# Altium schematic sheet Inresol_grid_inverter_DSPIC.SchDoc(Inresol_grid_inverter_DSPIC)
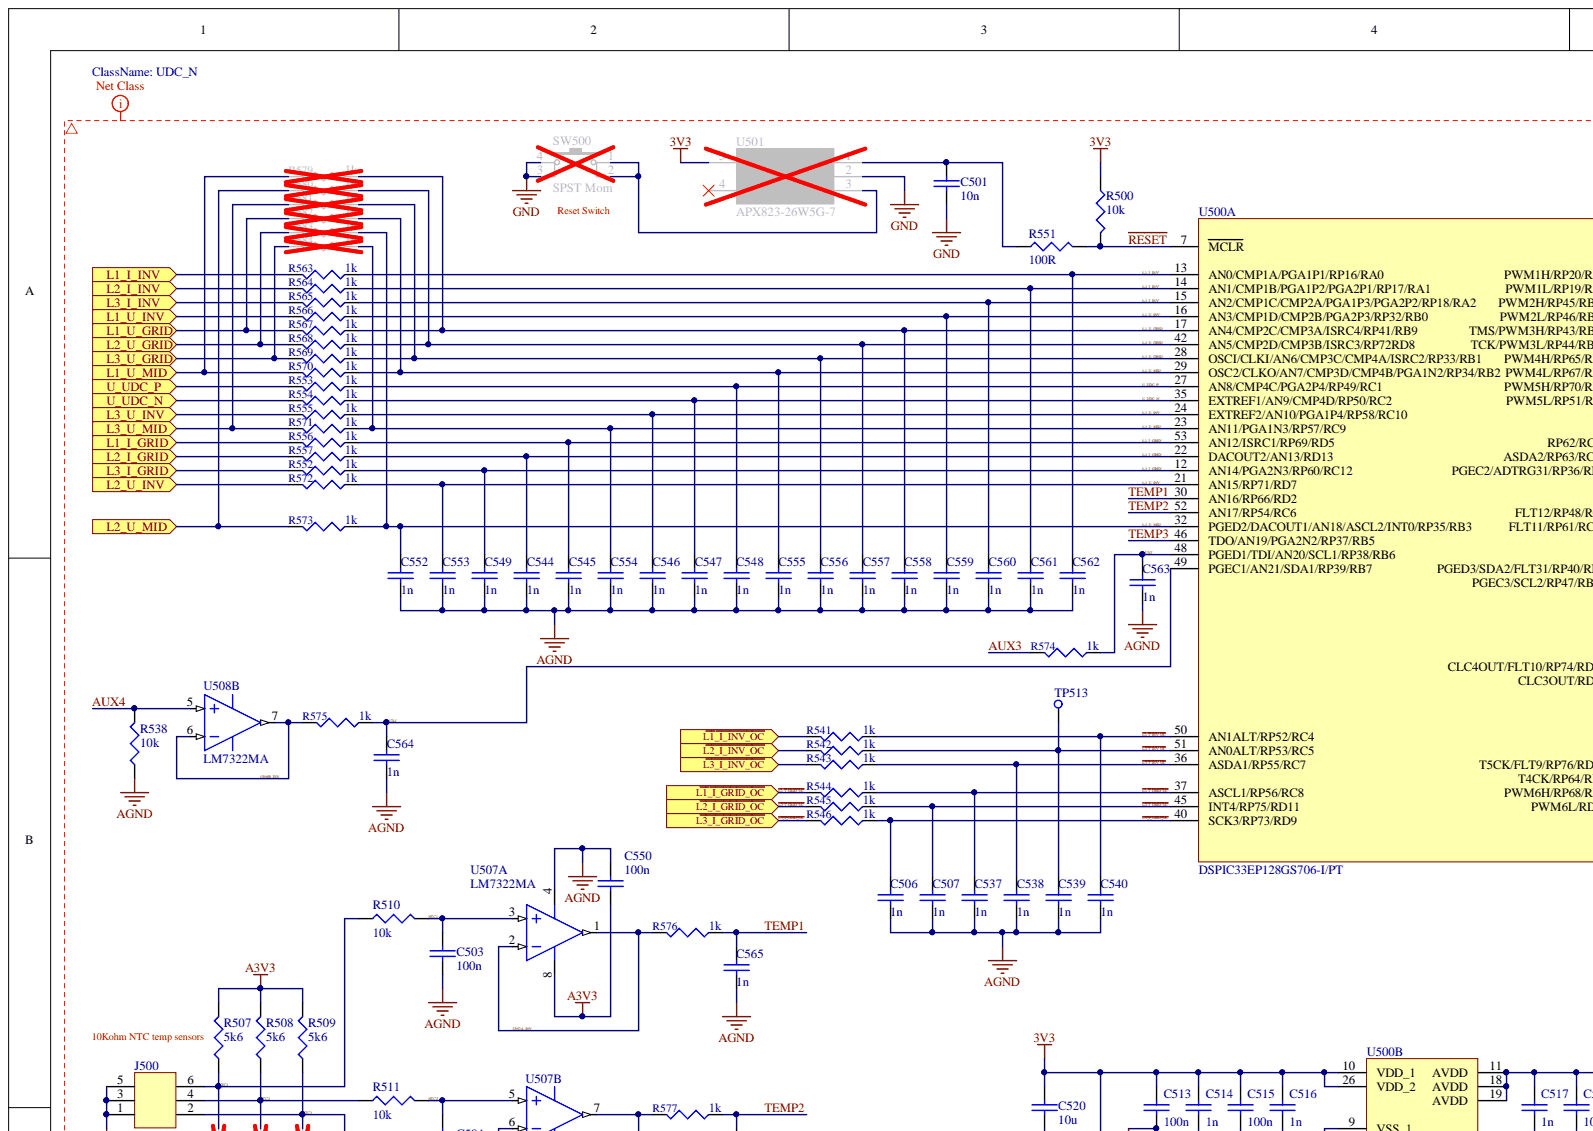
[diagram p0: Inresol_grid_inverter_DSPIC.SchDoc, top left]
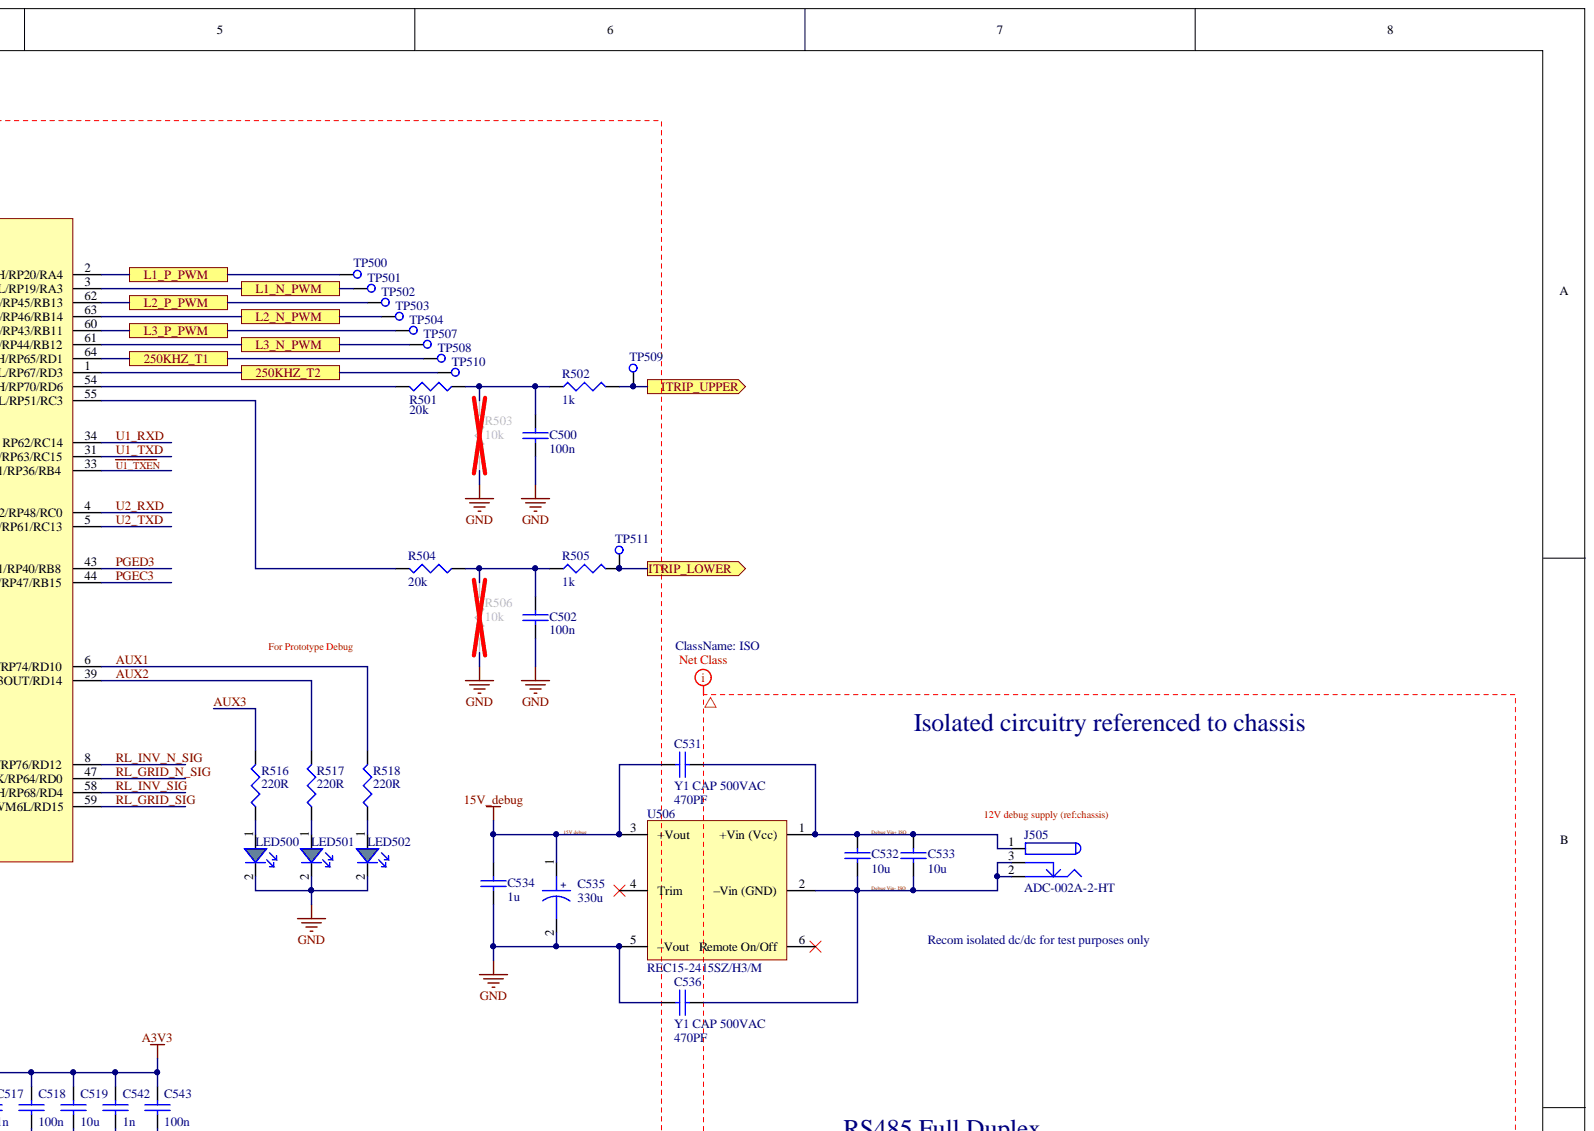
[diagram p1: Inresol_grid_inverter_DSPIC.SchDoc, top right]
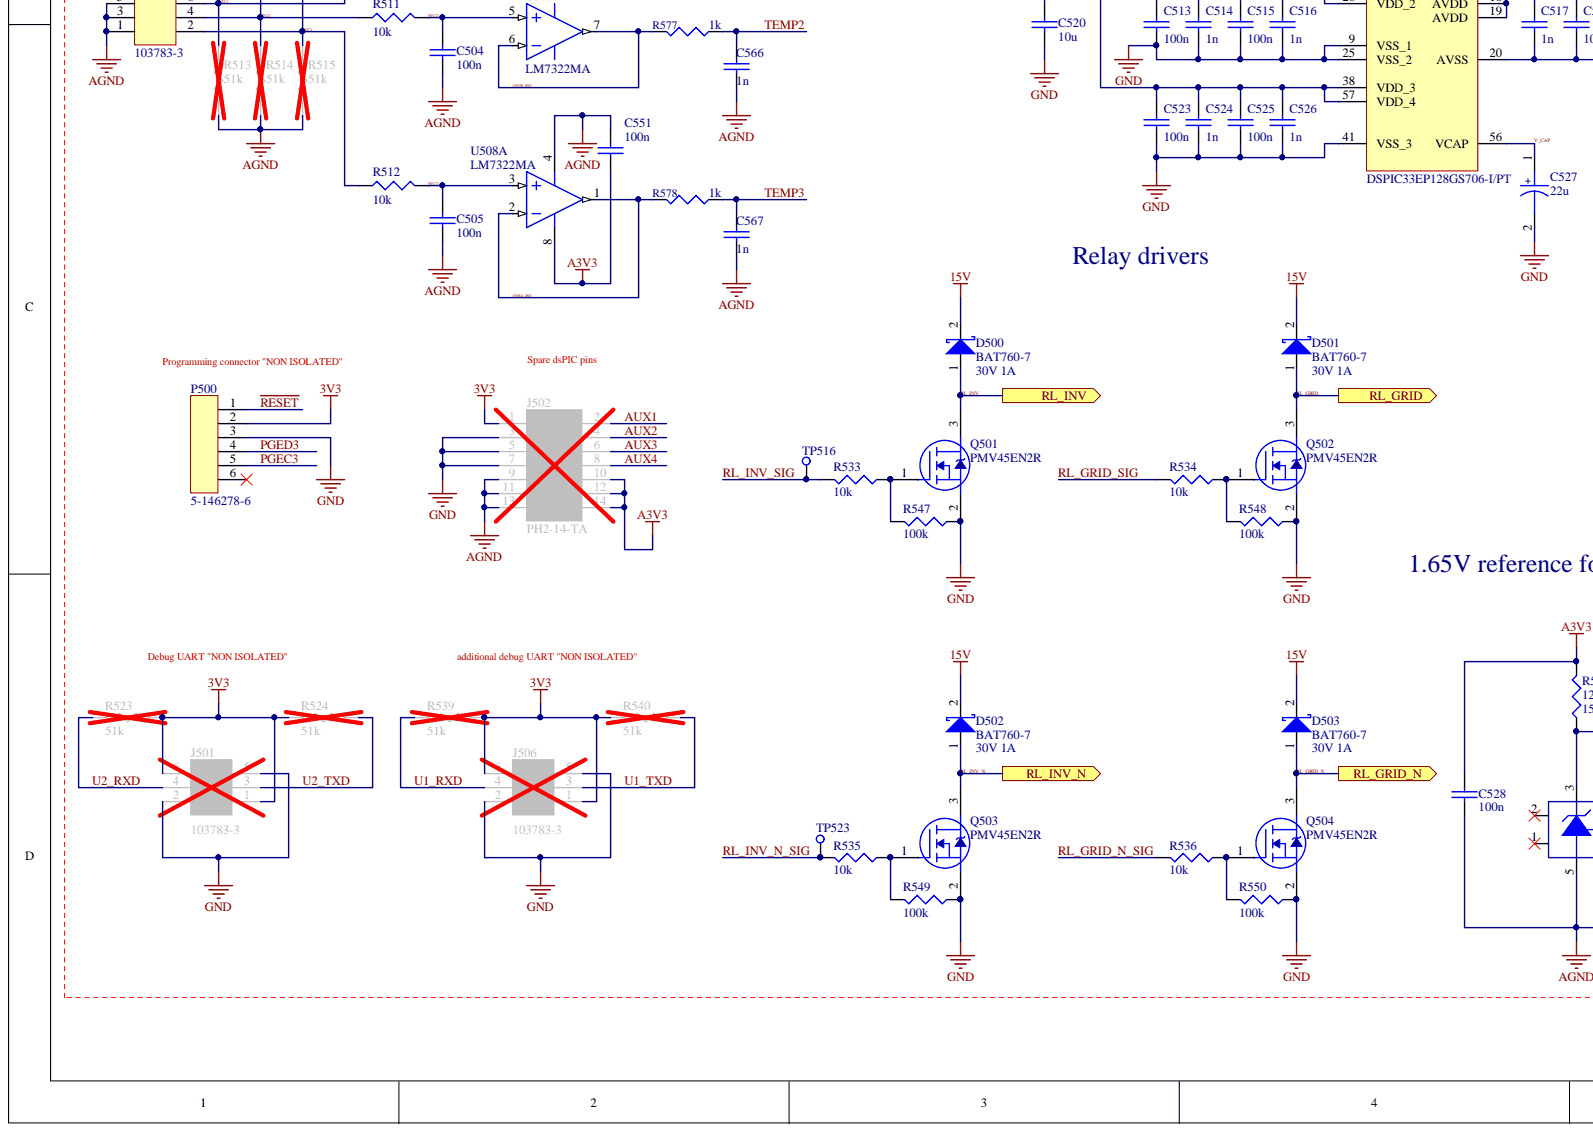
[diagram p2: Inresol_grid_inverter_DSPIC.SchDoc, bottom left]
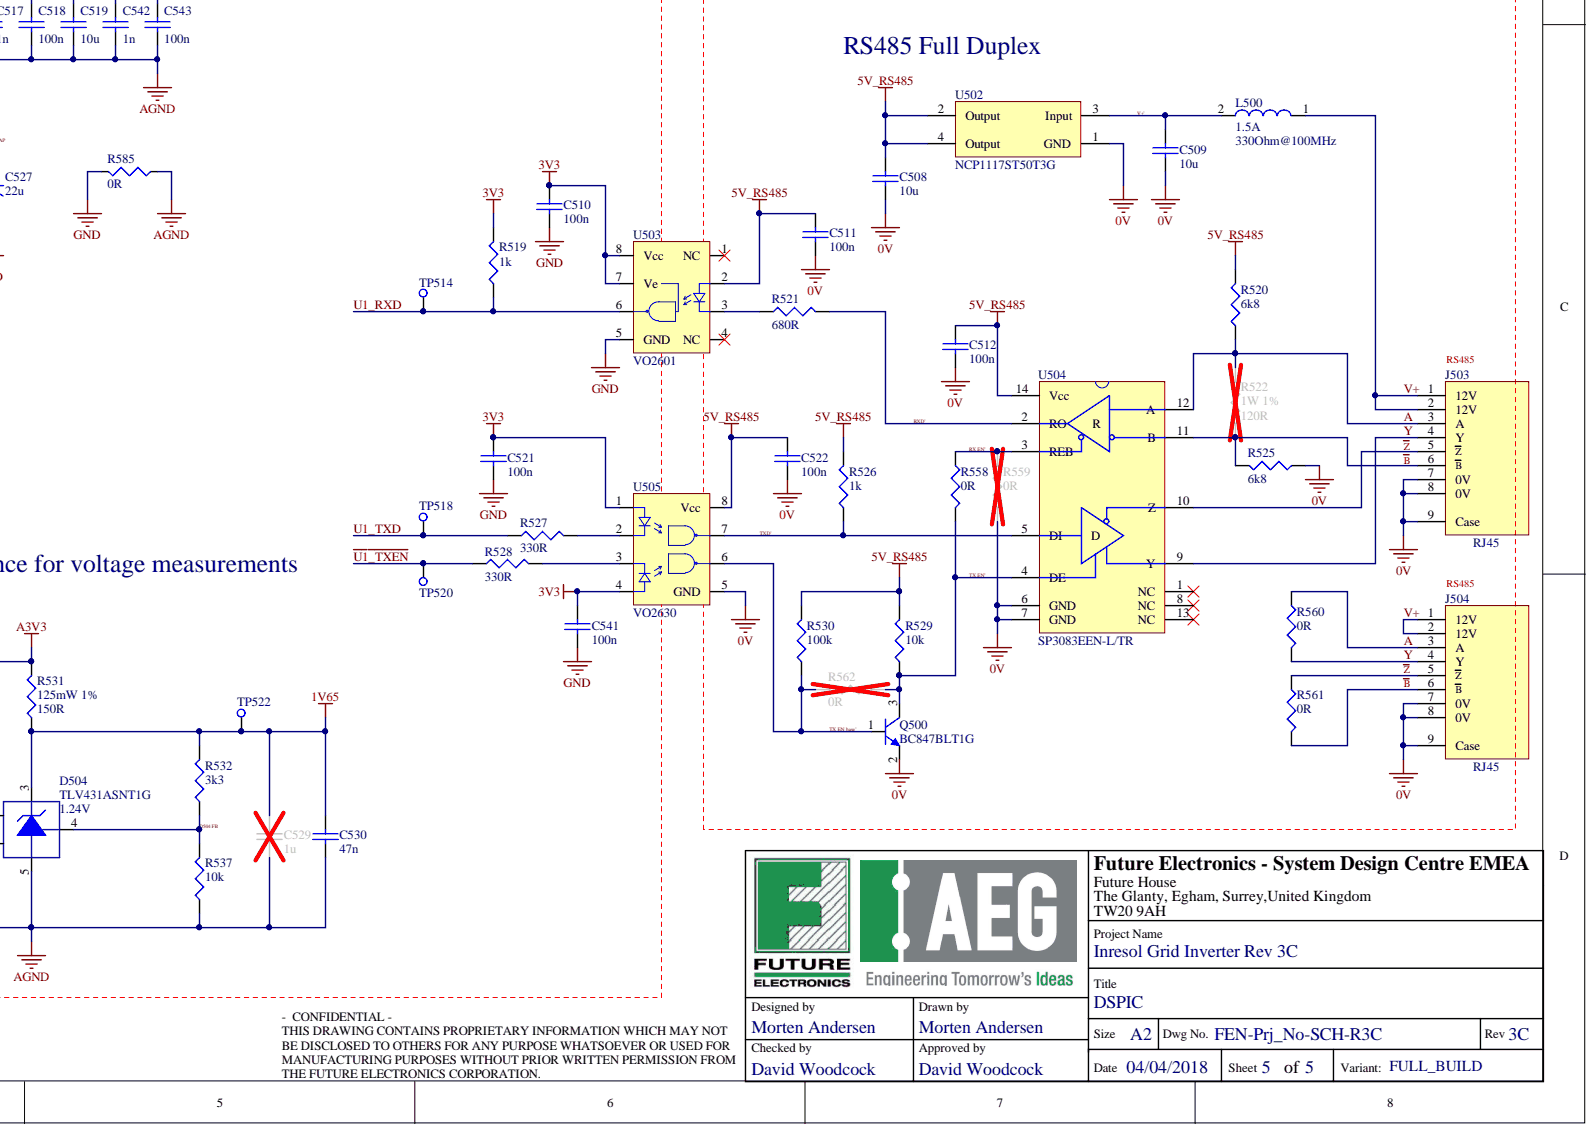
[diagram p3: Inresol_grid_inverter_DSPIC.SchDoc, bottom right]

## Parts
C500  value 100n  [0603]  (hidden comment: C-100nF-50V-Cer-0603)
C501  value 10n  [0603]  (hidden comment: C-10nF-25V-Cer-0603)
C502  value 100n  [0603]  (hidden comment: C-100nF-50V-Cer-0603)
C503  value 100n  [0603]  (hidden comment: C-100nF-50V-Cer-0603)
C504  value 100n  [0603]  (hidden comment: C-100nF-50V-Cer-0603)
C505  value 100n  [0603]  (hidden comment: C-100nF-50V-Cer-0603)
C506  value 1n  [0603]  (hidden comment: C-1nF-50V-Cer-0603)
C507  value 1n  [0603]  (hidden comment: C-1nF-50V-Cer-0603)
C508  value 10u  [1206]  (hidden comment: C-10uF-10V-Tant-1206)
C509  value 10u  [1206]  (hidden comment: C-10uF-50V-Cer-1206)
C510  value 100n  [0603]  (hidden comment: C-100nF-50V-Cer-0603)
C511  value 100n  [0603]  (hidden comment: C-100nF-50V-Cer-0603)
C512  value 100n  [0603]  (hidden comment: C-100nF-50V-Cer-0603)
C513  value 100n  [0603]  (hidden comment: C-100nF-50V-Cer-0603)
C514  value 1n  [0603]  (hidden comment: C-1nF-50V-Cer-0603)
C515  value 100n  [0603]  (hidden comment: C-100nF-50V-Cer-0603)
C516  value 1n  [0603]  (hidden comment: C-1nF-50V-Cer-0603)
C517  value 1n  [0603]  (hidden comment: C-1nF-50V-Cer-0603)
C518  value 100n  [0603]  (hidden comment: C-100nF-50V-Cer-0603)
C519  value 10u  [1206]  (hidden comment: C-10uF-10V-Cer-1206)
C520  value 10u  [1206]  (hidden comment: C-10uF-10V-Cer-1206)
C521  value 100n  [0603]  (hidden comment: C-100nF-50V-Cer-0603)
C522  value 100n  [0603]  (hidden comment: C-100nF-50V-Cer-0603)
C523  value 100n  [0603]  (hidden comment: C-100nF-50V-Cer-0603)
C524  value 1n  [0603]  (hidden comment: C-1nF-50V-Cer-0603)
C525  value 100n  [0603]  (hidden comment: C-100nF-50V-Cer-0603)
C526  value 1n  [0603]  (hidden comment: C-1nF-50V-Cer-0603)
C527  value 22u  [1210(C3225)L-Tant]  (hidden comment: C-22uF-16V-Tant-1210)
C528  value 100n  [0603]  (hidden comment: C-100nF-50V-Cer-0603)
C529  value 1u  [0603]  (hidden comment: C-1uF-25V-Cer-0603)
C530  value 47n  [0603]  (hidden comment: C-47nF-50V-Cer-0603)
C531  value 470PF  Rating: Y1 CAP 500VAC  [C-10.5x8.5_LS10]  (hidden comment: C-470PF-500VAC-Cer-8X5-LS10-Y1-EMEA-06-09-2017)
C532  value 10u  [1206]  (hidden comment: C-10uF-50V-Cer-1206)
C533  value 10u  [1206]  (hidden comment: C-10uF-50V-Cer-1206)
C534  value 1u  [0603]  (hidden comment: C-1uF-25V-Cer-0603)
C535  value 330u  [C-SM_R8x10]  (hidden comment: C-330uF-25V-Elec-8x10-EMEA-06-09-2017)
C536  value 470PF  Rating: Y1 CAP 500VAC  [C-10.5x8.5_LS10]  (hidden comment: C-470PF-500VAC-Cer-8X5-LS10-Y1-EMEA-06-09-2017)
C537  value 1n  [0603]  (hidden comment: C-1nF-50V-Cer-0603)
C538  value 1n  [0603]  (hidden comment: C-1nF-50V-Cer-0603)
C539  value 1n  [0603]  (hidden comment: C-1nF-50V-Cer-0603)
C540  value 1n  [0603]  (hidden comment: C-1nF-50V-Cer-0603)
C541  value 100n  [0603]  (hidden comment: C-100nF-50V-Cer-0603)
C542  value 1n  [0603]  (hidden comment: C-1nF-50V-Cer-0603)
C543  value 100n  [0603]  (hidden comment: C-100nF-50V-Cer-0603)
C544  value 1n  [0603]  (hidden comment: C-1nF-50V-Cer-0603)
C545  value 1n  [0603]  (hidden comment: C-1nF-50V-Cer-0603)
C546  value 1n  [0603]  (hidden comment: C-1nF-50V-Cer-0603)
C547  value 1n  [0603]  (hidden comment: C-1nF-50V-Cer-0603)
C548  value 1n  [0603]  (hidden comment: C-1nF-50V-Cer-0603)
C549  value 1n  [0603]  (hidden comment: C-1nF-50V-Cer-0603)
C550  value 100n  [0603]  (hidden comment: C-100nF-50V-Cer-0603)
C551  value 100n  [0603]  (hidden comment: C-100nF-50V-Cer-0603)
C552  value 1n  [0603]  (hidden comment: C-1nF-50V-Cer-0603)
C553  value 1n  [0603]  (hidden comment: C-1nF-50V-Cer-0603)
C554  value 1n  [0603]  (hidden comment: C-1nF-50V-Cer-0603)
C555  value 1n  [0603]  (hidden comment: C-1nF-50V-Cer-0603)
C556  value 1n  [0603]  (hidden comment: C-1nF-50V-Cer-0603)
C557  value 1n  [0603]  (hidden comment: C-1nF-50V-Cer-0603)
C558  value 1n  [0603]  (hidden comment: C-1nF-50V-Cer-0603)
C559  value 1n  [0603]  (hidden comment: C-1nF-50V-Cer-0603)
C560  value 1n  [0603]  (hidden comment: C-1nF-50V-Cer-0603)
C561  value 1n  [0603]  (hidden comment: C-1nF-50V-Cer-0603)
C562  value 1n  [0603]  (hidden comment: C-1nF-50V-Cer-0603)
C563  value 1n  [0603]  (hidden comment: C-1nF-50V-Cer-0603)
C564  value 1n  [0603]  (hidden comment: C-1nF-50V-Cer-0603)
C565  value 1n  [0603]  (hidden comment: C-1nF-50V-Cer-0603)
C566  value 1n  [0603]  (hidden comment: C-1nF-50V-Cer-0603)
C567  value 1n  [0603]  (hidden comment: C-1nF-50V-Cer-0603)
D500  MFR PN: BAT760-7  Rating: 30V 1A  [SOD323]  (hidden comment: D-SOD323-BAT760-7)
D501  MFR PN: BAT760-7  Rating: 30V 1A  [SOD323]  (hidden comment: D-SOD323-BAT760-7)
D502  MFR PN: BAT760-7  Rating: 30V 1A  [SOD323]  (hidden comment: D-SOD323-BAT760-7)
D503  MFR PN: BAT760-7  Rating: 30V 1A  [SOD323]  (hidden comment: D-SOD323-BAT760-7)
D504  MFR PN: TLV431ASNT1G  Rating: 1.24V  [TSOP5]  (hidden comment: D-TSOP5-VREF-TLV431A)
J500  MFR PN: 103783-3  [HDR100-2X3-TH]
J501  MFR PN: 103783-3  [HDR100-2X3-TH]
J502  MFR PN: PH2-14-TA  [HDR100-2X7-TH]  (hidden comment: J-HDR100-2x7-TH)
J503  value RJ45  [RJ-45-106066-2]  (hidden comment: J-RJ45-106066-2-EMEA-30-11-2016)
J504  value RJ45  [RJ-45-106066-2]  (hidden comment: J-RJ45-106066-2-EMEA-30-11-2016)
J505  MFR PN: ADC-002A-2-HT  [J-DCJACK_2.5]  (hidden comment: J-ADC-002A-1-2mm-EMEA-24-08-2017)
J506  MFR PN: 103783-3  [HDR100-2X3-TH]
L500  value 330Ohm@100MHz  Rating: 1.5A  [0805[2012]]  (hidden comment: 330 Ohm 1.5A)
LED500  LED-0603-Ever  [0603[1608] - DIODE]  (value not drawn)
LED501  LED-0603-Ever  [0603[1608] - DIODE]  (value not drawn)
LED502  LED-0603-Ever  [0603[1608] - DIODE]  (value not drawn)
P500  MFR PN: 5-146278-6  [HDR100-6PIN-TH]  (hidden comment: J-HDR100-6PIN-TH_TE)
Q500  value BC847BLT1G  MFR PN: BC847BLT1G  [SOT23]  (hidden comment: Q-SOT23-BC847BLT1G)
Q501  MFR PN: PMV45EN2R  [SOT23]  (hidden comment: Q-PMV45EN2R)
Q502  MFR PN: PMV45EN2R  [SOT23]  (hidden comment: Q-PMV45EN2R)
Q503  MFR PN: PMV45EN2R  [SOT23]  (hidden comment: Q-PMV45EN2R)
Q504  MFR PN: PMV45EN2R  [SOT23]  (hidden comment: Q-PMV45EN2R)
R500  value 10k  [0603]  (hidden comment: R-10k-100mW-0603)
R501  value 20k  [0603]  (hidden comment: R-20k-100mW-0603)
R502  value 1k  [0603]  (hidden comment: R-1k-100mW-0603)
R503  value 10k  [0603]  (hidden comment: R-10k-100mW-0603)
R504  value 20k  [0603]  (hidden comment: R-20k-100mW-0603)
R505  value 1k  [0603]  (hidden comment: R-1k-100mW-0603)
R506  value 10k  [0603]  (hidden comment: R-10k-100mW-0603)
R507  value 5k6  [0603]  (hidden comment: R-5.6k-100mW-0603)
R508  value 5k6  [0603]  (hidden comment: R-5.6k-100mW-0603)
R509  value 5k6  [0603]  (hidden comment: R-5.6k-100mW-0603)
R510  value 10k  [0603]  (hidden comment: R-10k-100mW-0603)
R511  value 10k  [0603]  (hidden comment: R-10k-100mW-0603)
R512  value 10k  [0603]  (hidden comment: R-10k-100mW-0603)
R513  value 51k  [0603]  (hidden comment: R-51k-100mW-0603)
R514  value 51k  [0603]  (hidden comment: R-51k-100mW-0603)
R515  value 51k  [0603]  (hidden comment: R-51k-100mW-0603)
R516  value 220R  [0603]  (hidden comment: R-220R-100mW-0603)
R517  value 220R  [0603]  (hidden comment: R-220R-100mW-0603)
R518  value 220R  [0603]  (hidden comment: R-220R-100mW-0603)
R519  value 1k  [0603]  (hidden comment: R-1k-100mW-0603)
R520  value 6k8  [0603]  (hidden comment: R-6.8k-100mW-0603)
R521  value 680R  [0603]  (hidden comment: R-680R-100mW-0603)
R522  value 120R  Rating: 1W 1%  [2512]  (hidden comment: R-120R-1W-2512-EMEA-01-12-2016)
R523  value 51k  [0603]  (hidden comment: R-51k-100mW-0603)
R524  value 51k  [0603]  (hidden comment: R-51k-100mW-0603)
R525  value 6k8  [0603]  (hidden comment: R-6.8k-100mW-0603)
R526  value 1k  [0603]  (hidden comment: R-1k-100mW-0603)
R527  value 330R  [0603]  (hidden comment: R-330R-100mW-0603)
R528  value 330R  [0603]  (hidden comment: R-330R-100mW-0603)
R529  value 10k  [0603]  (hidden comment: R-10k-100mW-0603)
R530  value 100k  [0603]  (hidden comment: R-100k-100mW-0603)
R531  value 150R  Rating: 125mW 1%  [0805]  (hidden comment: R-150R-125mW-0805)
R532  value 3k3  [0603]  (hidden comment: R-3.3k-100mW-0603)
R533  value 10k  [0603]  (hidden comment: R-10k-100mW-0603)
R534  value 10k  [0603]  (hidden comment: R-10k-100mW-0603)
R535  value 10k  [0603]  (hidden comment: R-10k-100mW-0603)
R536  value 10k  [0603]  (hidden comment: R-10k-100mW-0603)
R537  value 10k  [0603]  (hidden comment: R-10k-100mW-0603)
R538  value 10k  [0603]  (hidden comment: R-10k-100mW-0603)
R539  value 51k  [0603]  (hidden comment: R-51k-100mW-0603)
R540  value 51k  [0603]  (hidden comment: R-51k-100mW-0603)
R541  value 1k  [0603]  (hidden comment: R-1k-100mW-0603)
R542  value 1k  [0603]  (hidden comment: R-1k-100mW-0603)
R543  value 1k  [0603]  (hidden comment: R-1k-100mW-0603)
R544  value 1k  [0603]  (hidden comment: R-1k-100mW-0603)
R545  value 1k  [0603]  (hidden comment: R-1k-100mW-0603)
R546  value 1k  [0603]  (hidden comment: R-1k-100mW-0603)
R547  value 100k  [0603]  (hidden comment: R-100k-100mW-0603)
R548  value 100k  [0603]  (hidden comment: R-100k-100mW-0603)
R549  value 100k  [0603]  (hidden comment: R-100k-100mW-0603)
R550  value 100k  [0603]  (hidden comment: R-100k-100mW-0603)
R551  value 100R  [0603]  (hidden comment: R-100R-100mW-0603)
R552  value 1k  [0603]  (hidden comment: R-1k-100mW-0603)
R553  value 1k  [0603]  (hidden comment: R-1k-100mW-0603)
R554  value 1k  [0603]  (hidden comment: R-1k-100mW-0603)
R555  value 1k  [0603]  (hidden comment: R-1k-100mW-0603)
R556  value 1k  [0603]  (hidden comment: R-1k-100mW-0603)
R557  value 1k  [0603]  (hidden comment: R-1k-100mW-0603)
R558  value 0R  [0603]  (hidden comment: R-0R-100mW-0603)
R559  value 0R  [0603]  (hidden comment: R-0R-100mW-0603)
R560  value 0R  [0603]  (hidden comment: R-0R-100mW-0603)
R561  value 0R  [0603]  (hidden comment: R-0R-100mW-0603)
R562  value 0R  [0603]  (hidden comment: R-0R-100mW-0603)
R563  value 1k  [0603]  (hidden comment: R-1k-100mW-0603)
R564  value 1k  [0603]  (hidden comment: R-1k-100mW-0603)
R565  value 1k  [0603]  (hidden comment: R-1k-100mW-0603)
R566  value 1k  [0603]  (hidden comment: R-1k-100mW-0603)
R567  value 1k  [0603]  (hidden comment: R-1k-100mW-0603)
R568  value 1k  [0603]  (hidden comment: R-1k-100mW-0603)
R569  value 1k  [0603]  (hidden comment: R-1k-100mW-0603)
R570  value 1k  [0603]  (hidden comment: R-1k-100mW-0603)
R571  value 1k  [0603]  (hidden comment: R-1k-100mW-0603)
R572  value 1k  [0603]  (hidden comment: R-1k-100mW-0603)
R573  value 1k  [0603]  (hidden comment: R-1k-100mW-0603)
R574  value 1k  [0603]  (hidden comment: R-1k-100mW-0603)
R575  value 1k  [0603]  (hidden comment: R-1k-100mW-0603)
R576  value 1k  [0603]  (hidden comment: R-1k-100mW-0603)
R577  value 1k  [0603]  (hidden comment: R-1k-100mW-0603)
R578  value 1k  [0603]  (hidden comment: R-1k-100mW-0603)
R579  value 1k  [0603]  (hidden comment: R-1k-100mW-0603)
R580  value 1k  [0603]  (hidden comment: R-1k-100mW-0603)
R581  value 1k  [0603]  (hidden comment: R-1k-100mW-0603)
R582  value 1k  [0603]  (hidden comment: R-1k-100mW-0603)
R583  value 1k  [0603]  (hidden comment: R-1k-100mW-0603)
R584  value 1k  [0603]  (hidden comment: R-1k-100mW-0603)
R585  value 0R  [1206]  (hidden comment: R-0R-250mW-1206)
SW500  value SPST Mom  [SW-MOM-SMD-emea-18-5-15]  (hidden comment: SW-SPST-MOM-2-1437565-7-emea-18-5-15)
TP500  TP-TEST POINT-LOOP WT-EMEA-15-10-15  [SMD test point round]  (value not drawn)  (no BOM)
TP501  TP-TEST POINT-LOOP WT-EMEA-15-10-15  [SMD test point round]  (value not drawn)  (no BOM)
TP502  TP-TEST POINT-LOOP WT-EMEA-15-10-15  [SMD test point round]  (value not drawn)  (no BOM)
TP503  TP-TEST POINT-LOOP WT-EMEA-15-10-15  [SMD test point round]  (value not drawn)  (no BOM)
TP504  TP-TEST POINT-LOOP WT-EMEA-15-10-15  [SMD test point round]  (value not drawn)  (no BOM)
TP507  TP-TEST POINT-LOOP WT-EMEA-15-10-15  [SMD test point round]  (value not drawn)  (no BOM)
TP508  TP-TEST POINT-LOOP WT-EMEA-15-10-15  [SMD test point round]  (value not drawn)  (no BOM)
TP509  TP-TEST POINT-LOOP WT-EMEA-15-10-15  [SMD test point round]  (value not drawn)  (no BOM)
TP510  TP-TEST POINT-LOOP WT-EMEA-15-10-15  [SMD test point round]  (value not drawn)  (no BOM)
TP511  TP-TEST POINT-LOOP WT-EMEA-15-10-15  [SMD test point round]  (value not drawn)  (no BOM)
TP513  TP-TEST POINT-LOOP WT-EMEA-15-10-15  [SMD test point round]  (value not drawn)  (no BOM)
TP514  TP-TEST POINT-LOOP WT-EMEA-15-10-15  [SMD test point round]  (value not drawn)  (no BOM)
TP516  TP-TEST POINT-LOOP WT-EMEA-15-10-15  [SMD test point round]  (value not drawn)  (no BOM)
TP518  TP-TEST POINT-LOOP WT-EMEA-15-10-15  [SMD test point round]  (value not drawn)  (no BOM)
TP520  TP-TEST POINT-LOOP WT-EMEA-15-10-15  [SMD test point round]  (value not drawn)  (no BOM)
TP522  TP-TEST POINT-LOOP WT-EMEA-15-10-15  [SMD test point round]  (value not drawn)  (no BOM)
TP523  TP-TEST POINT-LOOP WT-EMEA-15-10-15  [SMD test point round]  (value not drawn)  (no BOM)
U500  MFR PN: DSPIC33EP128GS706-I/PT  [TQFP64 Exposed pad_10x10]  (hidden comment: U-TQFP64-dsPIC33EP128GS706)  (parts A,B)
U501  MFR PN: APX823-26W5G-7  [SOT23-5]  (hidden comment: Microprocessor Reset Circuit 3.08V)
U502  MFR PN: NCP1117ST50T3G  [SOT223]  (hidden comment: U-NCP1117ST50T3G-EMEA-24-08-2017)
U503  value VO2601  [SO8]  (hidden comment: U-SO8-VO2601-X007T-EMEA-15-03-2017)
U504  MFR PN: SP3083EEN-L/TR  [SO14]  (hidden comment: U-SO14-SP3083-EMEA-07-09-2017)
U505  value VO2630  [SO8]  (hidden comment: U-SO8-VO2630-X007T-EMEA-15-03-2017)
U506  MFR PN: REC15-2415SZ/H3/M  [Module 50.8mm x 25.4mm]  (hidden comment: 15W 3kVDC Vin: 9-36V; Vout:15V;)
U507  value LM7322MA  MFR PN: LM7322MA  [SO8]  (hidden comment: U-SOIC8-LM7322MA-EMEA)  (parts A,B)
U508  value LM7322MA  MFR PN: LM7322MA  [SO8]  (hidden comment: U-SOIC8-LM7322MA-EMEA)  (parts A,B)

## Ports
250KHZ_T1, 250KHZ_T2, ITRIP_LOWER, ITRIP_UPPER, L1_I_GRID, L1_I_INV, L1_N_PWM, L1_P_PWM, L1_U_GRID, L1_U_INV, L1_U_MID, L2_I_GRID, L2_I_INV, L2_N_PWM, L2_P_PWM, L2_U_GRID, L2_U_INV, L2_U_MID, L3_I_GRID, L3_I_INV, L3_N_PWM, L3_P_PWM, L3_U_GRID, L3_U_INV, L3_U_MID, RL_GRID, RL_GRID_N, RL_INV, RL_INV_N, U_UDC_N, U_UDC_P, ~{L1_I_GRID_OC}, ~{L1_I_INV_OC}, ~{L2_I_GRID_OC}, ~{L2_I_INV_OC}, ~{L3_I_GRID_OC}, ~{L3_I_INV_OC}

## Nets
0V: C508.2 C509.2 C511.2 C512.2 C522.2 J503.7(0V) J503.8(0V) J503.9(Case) J504.7(0V) J504.8(0V) J504.9(Case) Q500.2 R525.1 R559.2 U502.1(GND) U504.6(GND) U504.7(GND) U505.5(GND)
1V65: C529.1 C530.1 D504.3 R531.1 R532.2 TP522.1
3V3: C510.1 C513.1 C514.1 C515.1 C516.1 C520.1 C521.1 C523.1 C524.1 C525.1 C526.1 C541.1 J501.1 J501.6 J502.1 J506.1 J506.6 P500.2 R500.2 R519.2 R523.1 R524.2 R539.1 R540.2 U500.10(VDD_1) U500.26(VDD_2) U500.38(VDD_3) U500.57(VDD_4) U501.5(VCC) U503.7(Ve) U503.8(Vcc) U505.1 U505.4
5V_RS485: C508.1 C511.1 C512.1 C522.1 R520.2 R526.2 R529.2 R530.2 U502.2(Output) U502.4(Output) U503.2 U504.14(Vcc) U505.8(Vcc)
15V: D500.2 D501.2 D502.2 D503.2
A3V3: C517.1 C518.1 C519.1 C528.1 C542.1 C543.1 C550.2 C551.2 J502.10 J502.12 J502.14 R507.2 R508.2 R509.2 R531.2 U500.11(AVDD) U500.18(AVDD) U500.19(AVDD) U507.8 U508.8
AGND: C503.2 C504.2 C505.2 C506.2 C507.2 C517.2 C518.2 C519.2 C528.2 C529.2 C530.2 C537.2 C538.2 C539.2 C540.2 C542.2 C543.2 C544.2 C545.2 C546.2 C547.2 C548.2 C549.2 C550.1 C551.1 C552.2 C553.2 C554.2 C555.2 C556.2 C557.2 C558.2 C559.2 C560.2 C561.2 C562.2 C563.2 C564.2 C565.2 C566.2 C567.2 D504.5 J500.1 J500.3 J500.5 J502.9 J502.11 J502.13 R513.2 R514.2 R515.2 R537.1 R538.1 R585.1 U500.20(AVSS) U507.4 U508.4
GND: C500.2 C501.2 C502.2 C510.2 C513.2 C514.2 C515.2 C516.2 C520.2 C521.2 C523.2 C524.2 C525.2 C526.2 C527.2 C534.2 C535.2 C536.2 C541.2 J501.2 J501.5 J502.3 J502.5 J502.7 J506.2 J506.5 LED500.2 LED501.2 LED502.2 P500.3 Q501.2 Q502.2 Q503.2 Q504.2 R503.1 R506.1 R547.1 R548.1 R549.1 R550.1 R585.2 SW500.3 SW500.4 U500.9(VSS_1) U500.25(VSS_2) U500.41(VSS_3) U501.2(Gnd) U503.5(GND) U506.5(–Vout)
15V DEBUG_: C531.2 C534.1 C535.1 U506.3(+Vout)
250KHZ_T1: TP508.1 U500.64(PWM4H/RP65/RD1) ; port 250KHZ_T1
250KHZ_T2: TP510.1 U500.1(PWM4L/RP67/RD3) ; port 250KHZ_T2
A: J503.3(A) J504.3(A) R520.1 R522.2 R560.1 U504.12(A)
AUX1: J502.2 R518.1 U500.6(CLC4OUT/FLT10/RP74/RD10)
AUX2: J502.4 R517.1 U500.39(CLC3OUT/RD14)
AUX3: J502.6 R516.1 R574.2
AUX3': C563.1 R574.1 U500.48(PGED1/TDI/AN20/SCL1/RP38/RB6)
AUX4: J502.8 R538.2 U508.5
AUX4': C564.1 R575.1 U500.49(PGEC1/AN21/SDA1/RP39/RB7)
~{B}: J503.6(B) J504.6(B) R522.1 R525.2 R561.2 U504.11(B)
D504 FB: D504.4 R532.1 R537.2
DEBUG VIN+ ISO: C531.1 C532.1 C533.1 J505.1 U506.1(+Vin_(Vcc))
DEBUG VIN- ISO: C532.2 C533.2 C536.1 J505.2 J505.3 U506.2(–Vin_(GND))
ITRIP_LOWER: R505.2 TP511.1 ; port ITRIP_LOWER
ITRIP_UPPER: R502.2 TP509.1 ; port ITRIP_UPPER
L1_I_GRID: R556.2 ; port L1_I_GRID
L1_I_GRID': C545.1 R556.1 U500.53(AN12/ISRC1/RP69/RD5)
L1_I_INV: R563.2 ; port L1_I_INV
L1_I_INV': C562.1 R563.1 U500.13(AN0/CMP1A/PGA1P1/RP16/RA0)
L1_N_PWM: TP501.1 U500.3(PWM1L/RP19/RA3) ; port L1_N_PWM
L1_P_PWM: TP500.1 U500.2(PWM1H/RP20/RA4) ; port L1_P_PWM
L1_U_GRID: R567.2 R582.2 ; port L1_U_GRID
L1_U_GRID': C558.1 R567.1 R579.1 U500.17(AN4/CMP2C/CMP3A/ISRC4/RP41/RB9)
L1_U_INV: R566.2 ; port L1_U_INV
L1_U_INV': C559.1 R566.1 U500.16(AN3/CMP1D/CMP2B/PGA2P3/RP32/RB0)
L1_U_MID: R570.2 R579.2 ; port L1_U_MID
L1_U_MID': C555.1 R570.1 R582.1 U500.29(OSC2/CLKO/AN7/CMP3D/CMP4B/PGA1N2/RP34/RB2)
L2_I_GRID: R557.2 ; port L2_I_GRID
L2_I_GRID': C544.1 R557.1 U500.22(DACOUT2/AN13/RD13)
L2_I_INV: R564.2 ; port L2_I_INV
L2_I_INV': C561.1 R564.1 U500.14(AN1/CMP1B/PGA1P2/PGA2P1/RP17/RA1)
L2_N_PWM: TP503.1 U500.63(PWM2L/RP46/RB14) ; port L2_N_PWM
L2_P_PWM: TP502.1 U500.62(PWM2H/RP45/RB13) ; port L2_P_PWM
L2_U_GRID: R568.2 R583.2 ; port L2_U_GRID
L2_U_GRID': C557.1 R568.1 R580.1 U500.42(AN5/CMP2D/CMP3B/ISRC3/RP72RD8)
L2_U_INV: R572.2 ; port L2_U_INV
L2_U_INV': C553.1 R572.1 U500.21(AN15/RP71/RD7)
L2_U_MID: R573.2 R580.2 ; port L2_U_MID
L2_U_MID': C552.1 R573.1 R583.1 U500.32(PGED2/DACOUT1/AN18/ASCL2/INT0/RP35/RB3)
L3_I_GRID: R552.2 ; port L3_I_GRID
L3_I_GRID': C549.1 R552.1 U500.12(AN14/PGA2N3/RP60/RC12)
L3_I_INV: R565.2 ; port L3_I_INV
L3_I_INV': C560.1 R565.1 U500.15(AN2/CMP1C/CMP2A/PGA1P3/PGA2P2/RP18/RA2)
L3_N_PWM: TP507.1 U500.61(TCK/PWM3L/RP44/RB12) ; port L3_N_PWM
L3_P_PWM: TP504.1 U500.60(TMS/PWM3H/RP43/RB11) ; port L3_P_PWM
L3_U_GRID: R569.2 R584.2 ; port L3_U_GRID
L3_U_GRID': C556.1 R569.1 R581.1 U500.28(OSCI/CLKI/AN6/CMP3C/CMP4A/ISRC2/RP33/RB1)
L3_U_INV: R555.2 ; port L3_U_INV
L3_U_INV': C546.1 R555.1 U500.24(EXTREF2/AN10/PGA1P4/RP58/RC10)
L3_U_MID: R571.2 R581.2 ; port L3_U_MID
L3_U_MID': C554.1 R571.1 R584.1 U500.23(AN11/PGA1N3/RP57/RC9)
~{L1_I_GRID_OC}: R544.2 ; port ~{L1_I_GRID_OC}
~{L1_I_GRID_OC}': C537.1 R544.1 U500.37(ASCL1/RP56/RC8)
~{L1_I_INV_OC}: R541.2 ; port ~{L1_I_INV_OC}
~{L1_I_INV_OC}': C540.1 R541.1 U500.50(AN1ALT/RP52/RC4)
~{L2_I_GRID_OC}: R545.2 ; port ~{L2_I_GRID_OC}
~{L2_I_GRID_OC}': C507.1 R545.1 U500.45(INT4/RP75/RD11)
~{L2_I_INV_OC}: R542.2 ; port ~{L2_I_INV_OC}
~{L2_I_INV_OC}': C539.1 R542.1 TP513.1 U500.51(AN0ALT/RP53/RC5)
~{L3_I_GRID_OC}: R546.2 ; port ~{L3_I_GRID_OC}
~{L3_I_GRID_OC}': C506.1 R546.1 U500.40(SCK3/RP73/RD9)
~{L3_I_INV_OC}: R543.2 ; port ~{L3_I_INV_OC}
~{L3_I_INV_OC}': C538.1 R543.1 U500.36(ASDA1/RP55/RC7)
NTC1: J500.6 R507.1 R510.2 R513.1
NTC1': C503.1 R510.1 U507.3
NTC2: J500.4 R508.1 R511.2 R514.1
NTC2': C504.1 R511.1 U507.5
NTC3: J500.2 R509.1 R512.2 R515.1
NTC3': C505.1 R512.1 U508.3
PGEC3: P500.5 U500.44(PGEC3/SCL2/RP47/RB15)
PGED3: P500.4 U500.43(PGED3/SDA2/FLT31/RP40/RB8)
~{RESET}: P500.1 R500.1 R551.2 U500.7(MCLR)
RL_GRID: D501.1 Q502.3 ; port RL_GRID
RL_GRID_N: D503.1 Q504.3 ; port RL_GRID_N
RL_GRID_N_SIG: R536.2 U500.47(T4CK/RP64/RD0)
RL_GRID_SIG: R534.2 U500.59(PWM6L/RD15)
RL_INV: D500.1 Q501.3 ; port RL_INV
RL_INV_N: D502.1 Q503.3 ; port RL_INV_N
RL_INV_N_SIG: R535.2 TP523.1 U500.8(T5CK/FLT9/RP76/RD12)
RL_INV_SIG: R533.2 TP516.1 U500.58(PWM6H/RP68/RD4)
RX EN': R558.1 R559.1 U504.3(REB)
RXD': R521.2 U504.2(RO)
TEMP1: C565.1 R576.1 U500.30(AN16/RP66/RD2)
TEMP2: C566.1 R577.1 U500.52(AN17/RP54/RC6)
TEMP3: C567.1 R578.1 U500.46(TDO/AN19/PGA2N2/RP37/RB5)
TX EN BASE': Q500.1 R530.1 R562.1 U505.6
TX EN': Q500.3 R529.1 R558.2 R562.2 U504.4(DE)
TXD': R526.1 U504.5(DI) U505.7
U1_RXD: J506.4 R519.1 R539.2 TP514.1 U500.34(RP62/RC14) U503.6
U1_TXD: J506.3 R527.1 R540.1 TP518.1 U500.31(ASDA2/RP63/RC15)
U2_RXD: J501.4 R523.2 U500.4(FLT12/RP48/RC0)
U2_TXD: J501.3 R524.1 U500.5(FLT11/RP61/RC13)
U507A_INV: R576.2 U507.1 U507.2
U507B_INV: R577.2 U507.6 U507.7
U508A_INV: R578.2 U508.1 U508.2
U508B_INV: R575.2 U508.6 U508.7
~{U1_TXEN}: R528.1 TP520.1 U500.33(PGEC2/ADTRG31/RP36/RB4)
U_UDC_N: R554.2 ; port U_UDC_N
U_UDC_N': C547.1 R554.1 U500.35(EXTREF1/AN9/CMP4D/RP50/RC2)
U_UDC_P: R553.2 ; port U_UDC_P
U_UDC_P': C548.1 R553.1 U500.27(AN8/CMP4C/PGA2P4/RP49/RC1)
V+: J503.1(12V) J503.2(12V) J504.1(12V) J504.2(12V) L500.1
V+': C509.1 L500.2 U502.3(Input)
V_CAP: C527.1 U500.56(VCAP)
Y: J503.4(Y) J504.4(Y) R560.2 U504.9(Y)
~{Z}: J503.5(Z) J504.5(Z) R561.1 U504.10(Z)
NetC500_1: C500.1 R501.1 R502.1 R503.2
NetC501_1: C501.1 R551.1 U501.1(RESET)
NetC502_1: C502.1 R504.1 R505.1 R506.2
NetLED500_1: LED500.1 R516.2
NetLED501_1: LED501.1 R517.2
NetLED502_1: LED502.1 R518.2
NetQ501_1: Q501.1 R533.1 R547.2
NetQ502_1: Q502.1 R534.1 R548.2
NetQ503_1: Q503.1 R535.1 R549.2
NetQ504_1: Q504.1 R536.1 R550.2
NetR501_2: R501.2 U500.54(PWM5H/RP70/RD6)
NetR504_2: R504.2 U500.55(PWM5L/RP51/RC3)
NetR521_1: R521.1 U503.3
NetR527_2: R527.2 U505.2
NetR528_2: R528.2 U505.3
NetSW500_1: SW500.1 SW500.2 U501.3(MR)
N00073:
N00074:

Not connected: D504 1 2; P500 6; U501 4(WDI); U503 1(NC) 4(NC); U504 1(NC) 8(NC) 13(NC); U506 4(Trim) 6(Remote_On/Off)
